# Altium schematic sheet 07.USB.SchDoc
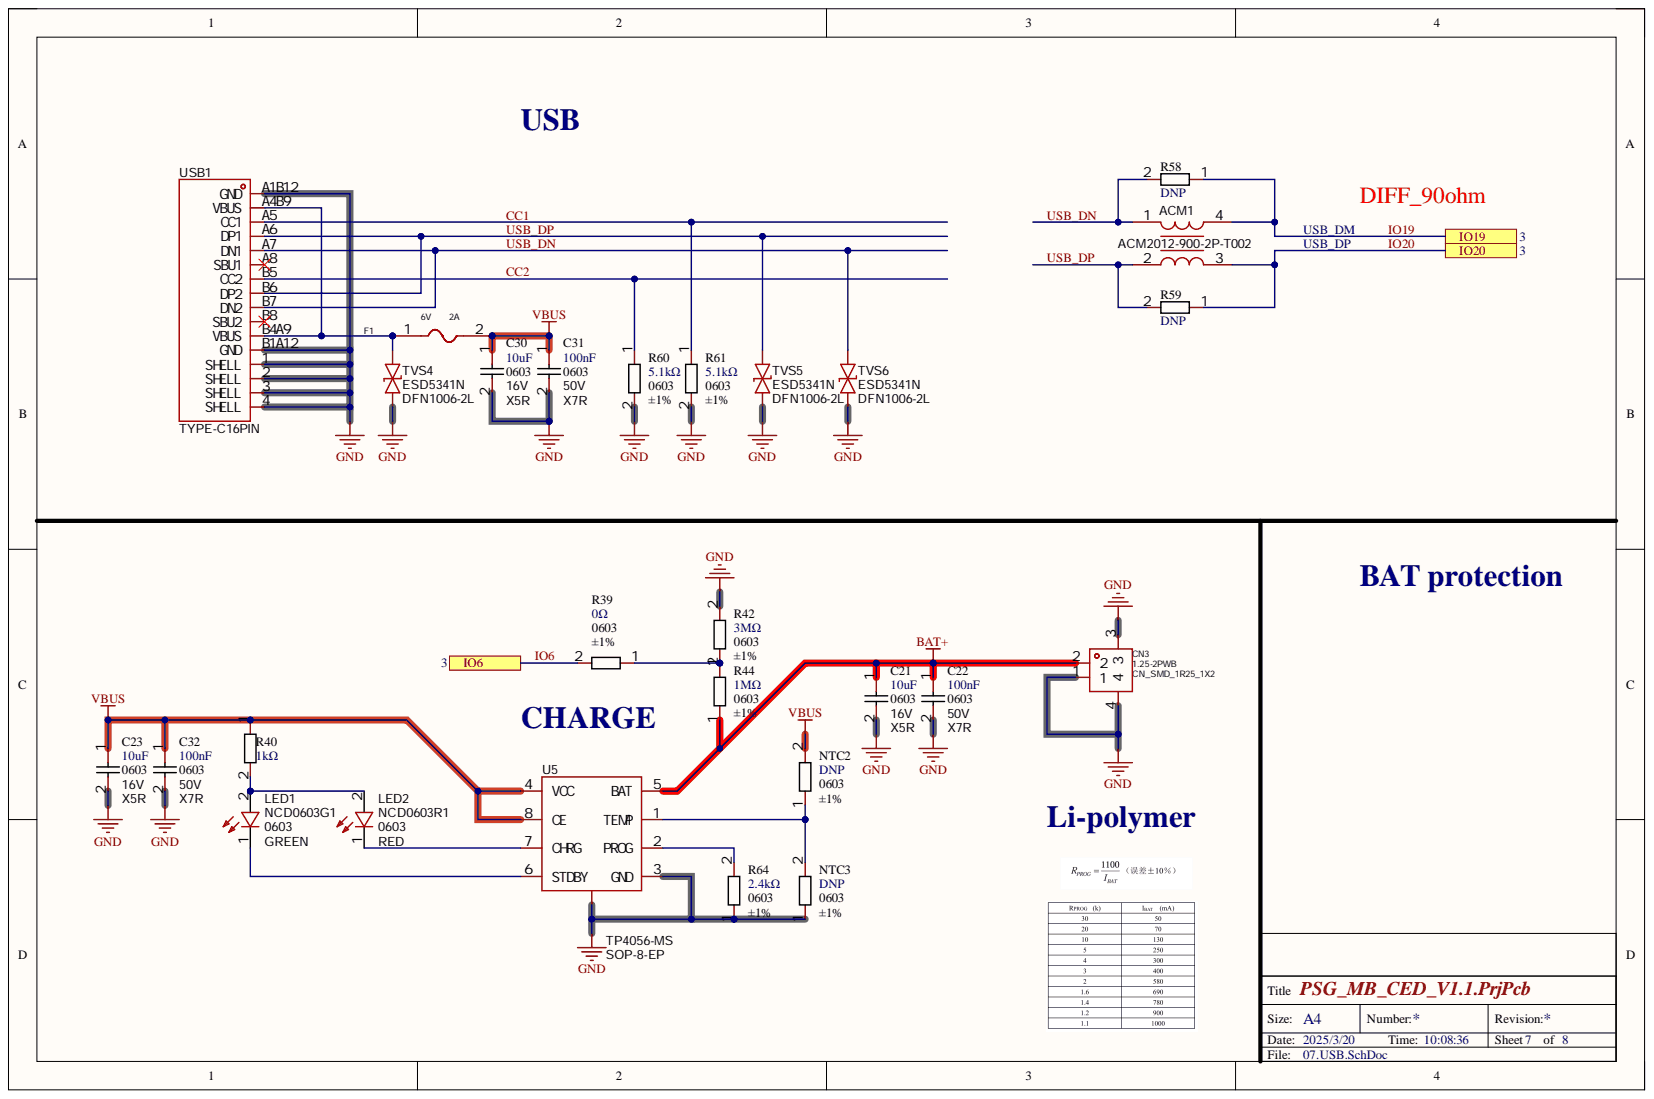
[diagram p0: 07.USB.SchDoc]

## Parts
ACM1  ACM2012-900-2P-T002  [ACM_SMD_4P_2012]
C21  10uF  Supplier Footprint: 0603  Temperature Coefficient: X5R  Voltage Rated: 16V  [C0603]
C22  100nF  Supplier Footprint: 0603  Temperature Coefficient: X7R  Voltage Rated: 50V  [C0603]
C23  10uF  Supplier Footprint: 0603  Temperature Coefficient: X5R  Voltage Rated: 16V  [C0603]
C30  10uF  Supplier Footprint: 0603  Temperature Coefficient: X5R  Voltage Rated: 16V  [C0603]
C31  100nF  Supplier Footprint: 0603  Temperature Coefficient: X7R  Voltage Rated: 50V  [C0603]
C32  100nF  Supplier Footprint: 0603  Temperature Coefficient: X7R  Voltage Rated: 50V  [C0603]
CN3  1.25-2PWB  [CN_SMD_1R25_1X2]
F1  Hold Current: 2A  Operating Voltage (Max): 6V  [F0805]  (hidden comment: SL0805200)
LED1  NCD0603G1  Emitted Color: GREEN  Supplier Footprint: 0603  [LED0603-G]
LED2  NCD0603R1  Emitted Color: RED  Supplier Footprint: 0603  [LED0603-R]
NTC2  DNP  Supplier Footprint: 0603  Tolerance: ±1%  [R0603]
NTC3  DNP  Supplier Footprint: 0603  Tolerance: ±1%  [R0603]
R39  0Ω  Supplier Footprint: 0603  Tolerance: ±1%  [R0603]
R40  1kΩ  [R0603]
R42  3MΩ  Supplier Footprint: 0603  [R0603]
R44  1MΩ  Supplier Footprint: 0603  Tolerance: ±1%  [R0603]
R58  DNP  [R0603]
R59  DNP  [R0603]
R60  5.1kΩ  Supplier Footprint: 0603  Tolerance: ±1%  [R0603]
R61  5.1kΩ  Supplier Footprint: 0603  Tolerance: ±1%  [R0603]
R64  2.4kΩ  Supplier Footprint: 0603  Tolerance: ±1%  [R0603]
TVS4  ESD5341N  Supplier Footprint: DFN1006-2L  [DFN1006-2L-BI]
TVS5  ESD5341N  Supplier Footprint: DFN1006-2L  [DFN1006-2L-BI]
TVS6  ESD5341N  Supplier Footprint: DFN1006-2L  [DFN1006-2L-BI]
U5  TP4056-MS  [SOP-8-EP]
USB1  TYPE-C16PIN  [USB-C-SMD_TYPE-C16PIN]

## Ports
IO6, IO19, IO20

## Nets
BAT+: C21.1 C22.1 CN3.2 R44.1 U5.5(BAT)
GND: C21.2 C22.2 C23.2 C30.2 C31.2 C32.2 CN3.1 CN3.3 CN3.4 NTC3.1 R42.2 R60.2 R61.2 R64.1 TVS4.2 TVS5.2 TVS6.2 U5.3(GND) U5.9 USB1.1(SHELL) USB1.2(SHELL) USB1.3(SHELL) USB1.4(SHELL) USB1.A1B12(GND) USB1.B1A12(GND)
VBUS: C23.1 C30.1 C31.1 C32.1 F1.2 NTC2.2 R40.1 U5.4(VCC) U5.8(CE)
CC1: R61.1 USB1.A5(CC1)
CC2: R60.1 USB1.B5(CC2)
IO6: R39.2 ; port IO6
IO19: ACM1.4 R58.1 ; port IO19
IO20: ACM1.3 R59.1 ; port IO20
USB_DN: ACM1.1 R58.2 TVS6.1 USB1.A7(DN1) USB1.B7(DN2)
USB_DP: ACM1.2 R59.2 TVS5.1 USB1.A6(DP1) USB1.B6(DP2)
NetF1_1: F1.1 TVS4.1 USB1.A4B9(VBUS) USB1.B4A9(VBUS)
NetLED1_1: LED1.1 U5.6(STDBY)
NetLED1_2: LED1.2 LED2.2 R40.2
NetLED2_1: LED2.1 U5.7(CHRG)
NetNTC2_1: NTC2.1 NTC3.2 U5.1(TEMP)
NetR39_1: R39.1 R42.1 R44.2
NetR64_2: R64.2 U5.2(PROG)

Not connected: USB1 A8(SBU1) B8(SBU2)

## Library data of the parts
ACM1 = ACM2012-900-2P-T002: Manufacturer: TDK; Add into BOM: yes; Current Rating: 400mA; DC Resistance (DCR): 190mΩ; Impedance @ Frequency: 90Ω@100MHz; Number of Lines: 2; Voltage Rating - DC: 50V; Component Kind: Standard; Convert to PCB: yes; Datasheet: https://atta.szlcsc.com/upload/public/pdf/source/20200629/C19972_344527C0CEDC971B6C9D0CA9AC65ADB7.pdf; JLCPCB Part Class: Extended Part; LCSC Part Name: 两路 贴片共模滤波器 阻抗90Ω@100MHz 停产; Manufacturer Part: ACM2012-900-2P-T002; Operating Temperature: -25℃~+85℃; Supplier: LCSC; Supplier Part: C19972; Symbol: ACM2012-900-2P-T002; Value: ACM2012-900-2P-T002; Withstanding Voltage: 50V
C21 C23 C30 = CC0603JRNPO9BN120: Manufacturer: YAGEO(国巨); Add into BOM: yes; Device: CC0603MRX5R7BB106; Name: 贴片电容; Tolerance: ±20%; Component Kind: Standard; Convert to PCB: yes; Datasheet: https://atta.szlcsc.com/upload/public/pdf/source/20180326/C109457_7BA80561F4CBA4554497C3A4BE33D2CE.pdf; JLCPCB Part Class: Extended Part; LCSC Part Name: 10uF ±20% 16V; Manufacturer Part: CC0603MRX5R7BB106; Supplier: LCSC; Supplier Part: C109457; Symbol: CC0603MRX5R7BB106; Value: 10uF
C22 C31 C32 = CC0603JRNPO9BN120: Manufacturer: YAGEO(国巨); Add into BOM: yes; Device: CC0603KRX7R9BB104; Name: 贴片电容; Tolerance: ±10%; Component Kind: Standard; Convert to PCB: yes; Datasheet: https://atta.szlcsc.com/upload/public/pdf/source/20180910/C282072_33301C7F631E79921B82A8DAC6BCC5B3.pdf; JLCPCB Part Class: Basic Part; LCSC Part Name: 100nF ±10% 50V; Manufacturer Part: CC0603KRX7R9BB104; Supplier: LCSC; Supplier Part: C14663; Symbol: CC0603KRX7R9BB104; Value: 100nF
CN3 = WAFER-PH2.0-2PWB: Manufacturer: DEALON(德艺隆); Add into BOM: yes; Number of PINs Per Row: 2; Pins Structure: 1x2P; Pitch: 1.25mm; Component Kind: Standard; Convert to PCB: yes; Current Rating (Max): -; Datasheet: https://atta.szlcsc.com/upload/public/pdf/source/20231123/1AE0876AE9C7DCFC0D68EAC4CBA881B0.pdf; JLCPCB Part Class: Extended Part; LCSC Part Name: 1x2P 间距:1.25mm 卧贴; Manufacturer Part: 1.25-2PWB; Mounting Style: 卧贴; Number of Pins: 2P; Number of Rows: 1; Operating Temperature Range: -40℃~+85℃; PCB3D: CN_SMD_2R0_1X2; Supplier: LCSC; Supplier Footprint: CN_SMD_1R25_1X2; Supplier Part: C2905009; Symbol: 1.25-2PWB; Value: 1.25-2PWB
F1 = SL0805200: Manufacturer: 台湾陆海; Add into BOM: yes; Current Rating (Max): 50A; Maximum Time to Trip: 5s; Power Dissipation: 1W; Resistance - Initial (Ri) (Min): 8mΩ; Resistance - Post Trip (R1) (Max): 55mΩ; Trip Current: 4A; Component Kind: Standard; Convert to PCB: yes; Datasheet: https://atta.szlcsc.com/upload/public/pdf/source/20160823/1471947506254.pdf; JLCPCB Part Class: Extended Part; LCSC Part Name: 6V 2A 0805自恢复; Manufacturer Part: SL0805200; Operating Temperature: -40℃~+70℃; PCB3D: F0805_L2.1-W1.4-H0.8; Supplier: LCSC; Supplier Footprint: 0805; Supplier Part: C70158; Symbol: SL0805200; Value: SL0805200
LED1 = NCD0603R1_C84263: Manufacturer: 国星光电; Add into BOM: yes; Diode Configuration: 独立二极管; Dominant Wavelength: 515nm~530nm; Forward Current: 10mA; Forward Voltage (VF): 2.6V~3.6V; Lens Color: 无色透明透镜; Luminous Intensity: 330mcd~900mcd; Power Dissipation: 72mW; Symbol: FC-D1608UGK-520D10; Viewing Angle: 130°; Color Temperature: -; Component Kind: Standard; Convert to PCB: yes; Datasheet: https://atta.szlcsc.com/upload/public/pdf/source/20200820/C84267_04BA7703640BAC111D09F5EFB971FBF3.pdf; JLCPCB Part Class: Extended Part; Lamp Holder Type: -; LCSC Part Name: NCD0603G1; Manufacturer Part: NCD0603G1; Mounting Sytle: -; Operating Temperature: -30℃~+85℃; Peak Wavelength: -; Supplier: LCSC; Supplier Part: C84267; Value: NCD0603R1_C84263
LED2 = NCD0603R1_C84263: Manufacturer: 国星光电; Add into BOM: yes; Diode Configuration: 独立二极管; Dominant Wavelength: 615nm~630nm; Forward Current: 20mA; Forward Voltage (VF): 1.6V~2.6V; Lens Color: 无色透明透镜; Luminous Intensity: 80mcd~220mcd; Power Dissipation: 65mW; Symbol: FC-DA1608HRK-620D; Viewing Angle: 130°; Color Temperature: -; Component Kind: Standard; Convert to PCB: yes; Datasheet: https://atta.szlcsc.com/upload/public/pdf/source/20200820/C84263_DABC6A75E58F576973B407FDBA283D60.pdf; JLCPCB Part Class: Extended Part; Lamp Holder Type: -; LCSC Part Name: NCD0603R1; Manufacturer Part: NCD0603R1; Mounting Sytle: -; Operating Temperature: -30℃~+85℃; Peak Wavelength: -; Supplier: LCSC; Supplier Part: C84263; Value: NCD0603R1_C84263
NTC2 NTC3 = 0603WAF1003T5E: Manufacturer: YAGEO(国巨); Add into BOM: yes; Device: RC0603FR-07100KL; Name: 贴片电阻; Overload Voltage (Max): 75V; Power(Watts): 100mW; Temperature Coefficient: ±100ppm/℃; Component Kind: Standard; Convert to PCB: yes; Datasheet: https://atta.szlcsc.com/upload/public/pdf/source/20140324/1457707028752.pdf; JLCPCB Part Class: Extended Part; LCSC Part Name: 厚膜电阻 100kΩ ±1% 100mW; Manufacturer Part: RC0603FR-07100KL; Operating Temperature Range: -55℃~+155℃; Supplier: LCSC; Supplier Part: C14675; Symbol: RC0603FR-07100KL; Type: 厚膜电阻; Value: DNP
R39 = 0603WAF1003T5E: Manufacturer: YAGEO(国巨); Add into BOM: yes; Device: RC0603FR-070RL; Name: 贴片电阻; Overload Voltage (Max): 75V; Power(Watts): 100mW; Component Kind: Standard; Convert to PCB: yes; Datasheet: https://atta.szlcsc.com/upload/public/pdf/source/20180316/70C0E2BDAE7FCC62C531A5A58D202EE1.pdf; JLCPCB Part Class: Extended Part; LCSC Part Name: 厚膜电阻 0Ω ±1% 100mW; Manufacturer Part: RC0603FR-070RL; Operating Temperature Range: -55℃~+155℃; Supplier: LCSC; Supplier Part: C100044; Symbol: RC0603FR-070RL; Temperature Coefficient: -; Type: 厚膜电阻; Value: 0Ω
R40 = 0603WAF1003T5E: Manufacturer: YAGEO(国巨); Add into BOM: yes; Device: RC0603FR-071KL; Name: 贴片电阻; Overload Voltage (Max): 75V; Power(Watts): 100mW; Temperature Coefficient: ±100ppm/℃; Component Kind: Standard; Convert to PCB: yes; Datasheet: https://atta.szlcsc.com/upload/public/pdf/source/20180316/70C0E2BDAE7FCC62C531A5A58D202EE1.pdf; JLCPCB Part Class: Extended Part; LCSC Part Name: 厚膜电阻 1kΩ ±1% 100mW; Manufacturer Part: RC0603FR-071KL; Operating Temperature Range: -55℃~+155℃; Supplier: LCSC; Supplier Part: C22548; Symbol: RC0603FR-071KL; Type: 厚膜电阻; Value: 1kΩ
R42 = 0603WAF1003T5E: Manufacturer: YAGEO(国巨); Add into BOM: yes; Device: RC0603FR-073ML; Name: 贴片电阻; Overload Voltage (Max): 75V; Power(Watts): 100mW; Temperature Coefficient: ±100ppm/℃; Tolerance: ±1%; Component Kind: Standard; Convert to PCB: yes; Datasheet: https://atta.szlcsc.com/upload/public/pdf/source/20180821/C275644_0D56F95EFF785E7D9A1FEF436B37DA78.pdf; JLCPCB Part Class: Extended Part; LCSC Part Name: 厚膜电阻 3MΩ ±1% 100mW; Manufacturer Part: RC0603FR-073ML; Operating Temperature Range: -55℃~+155℃; Supplier: LCSC; Supplier Part: C126361; Symbol: RC0603FR-073ML; Type: 厚膜电阻; Value: 3MΩ
R44 = 0603WAF1003T5E: Manufacturer: YAGEO(国巨); Add into BOM: yes; Device: RC0603FR-071ML; Name: 贴片电阻; Overload Voltage (Max): 75V; Power(Watts): 100mW; Temperature Coefficient: ±100ppm/℃; Component Kind: Standard; Convert to PCB: yes; Datasheet: https://atta.szlcsc.com/upload/public/pdf/source/20181102/C105578_67A9388250AF143DE4682E62257D2969.pdf; JLCPCB Part Class: Extended Part; LCSC Part Name: 厚膜电阻 1MΩ ±1% 100mW; Manufacturer Part: RC0603FR-071ML; Operating Temperature Range: -55℃~+155℃; Supplier: LCSC; Supplier Part: C105578; Symbol: RC0603FR-071ML; Type: 厚膜电阻; Value: 1MΩ
R58 R59 = 0603WAF1003T5E: Manufacturer: YAGEO(国巨); Add into BOM: yes; Device: RC0603FR-0710KL; Name: 贴片电阻; Overload Voltage (Max): 75V; Power(Watts): 100mW; Temperature Coefficient: ±100ppm/℃; Component Kind: Standard; Convert to PCB: yes; Datasheet: https://atta.szlcsc.com/upload/public/pdf/source/20180927/C295883_E8D83085EA81BD7DE0B2B681138C15EE.pdf; JLCPCB Part Class: Extended Part; LCSC Part Name: 厚膜电阻 10kΩ ±1% 100mW; Manufacturer Part: RC0603FR-0710KL; Operating Temperature Range: -55℃~+155℃; Supplier: LCSC; Supplier Part: C98220; Symbol: RC0603FR-0710KL; Type: 厚膜电阻; Value: DNP
R60 R61 = 0603WAF1003T5E: Manufacturer: YAGEO(国巨); Add into BOM: yes; Device: RC0603FR-075K1L; Name: 贴片电阻; Overload Voltage (Max): 75V; Power(Watts): 100mW; Temperature Coefficient: ±100ppm/℃; Component Kind: Standard; Convert to PCB: yes; Datasheet: https://atta.szlcsc.com/upload/public/pdf/source/20180316/70C0E2BDAE7FCC62C531A5A58D202EE1.pdf; JLCPCB Part Class: Extended Part; LCSC Part Name: 厚膜电阻 5.1kΩ ±1% 100mW; Manufacturer Part: RC0603FR-075K1L; Operating Temperature Range: -55℃~+155℃; Supplier: LCSC; Supplier Part: C105580; Symbol: RC0603FR-075K1L; Type: 厚膜电阻; Value: 5.1kΩ
R64 = 0603WAF1003T5E: Manufacturer: YAGEO(国巨); Add into BOM: yes; Device: RC0603FR-072K4L; Name: 贴片电阻; Overload Voltage (Max): 75V; Power(Watts): 100mW; Temperature Coefficient: ±100ppm/℃; Component Kind: Standard; Convert to PCB: yes; Datasheet: https://atta.szlcsc.com/upload/public/pdf/source/20180821/C275644_0D56F95EFF785E7D9A1FEF436B37DA78.pdf; JLCPCB Part Class: Extended Part; LCSC Part Name: 厚膜电阻 2.4kΩ ±1% 100mW; Manufacturer Part: RC0603FR-072K4L; Operating Temperature Range: -55℃~+155℃; Supplier: LCSC; Supplier Part: C114611; Symbol: RC0603FR-072K4L; Type: 厚膜电阻; Value: 2.4kΩ
TVS4-TVS6 = ESD5341N_C20612964: Manufacturer: KUU; Add into BOM: yes; Component Kind: Standard; Convert to PCB: yes; Datasheet: https://atta.szlcsc.com/upload/public/pdf/source/20240126/9EE8C033ACD76A81C21292FAA1DFE4C1.pdf; JLCPCB Part Class: Extended Part; LCSC Part Name: ESD静电保护管; Manufacturer Part: ESD5341N; Supplier: LCSC; Supplier Part: C20612964; Symbol: ESD5341N_C20612964; Value: ESD5341N
U5 = TP4056-42-EMSOP8: Manufacturer: MSKSEMI(美森科); Add into BOM: yes; Component Kind: Standard; Convert to PCB: yes; Datasheet: https://atta.szlcsc.com/upload/public/pdf/source/20230808/7F9175670C53D6763A7A5691214AEA38.pdf; JLCPCB Part Class: Extended Part; LCSC Part Name: TP4056-MS; Manufacturer Part: TP4056-MS; PCB3D: MSOP-8_L3.0-W3.0-P0.65-LS5.0-BR-EP; Supplier: LCSC; Supplier Footprint: SOP-8-EP; Supplier Part: C7473158; Symbol: TP4056-MS; Value: TP4056-MS
USB1 = TYPE-C16PIN: Manufacturer: SHOU HAN(首韩); Add into BOM: yes; Connect-Disconnect Life: 1万次; Connector Type: Type-C; Core Colour: 黑色; Current Rating - Power (Max): 3A; Gender: 母; Number of Contacts: 16P; Number of Ports: 1; Rated Voltage (Max): 30V; Component Kind: Standard; Convert to PCB: yes; Datasheet: https://atta.szlcsc.com/upload/public/pdf/source/20190521/C393939_7B9043D4CF1026AF443AB60D91FBCD5C.pdf; JLCPCB Part Class: Extended Part; LCSC Part Name: Type-C 母 卧贴; Manufacturer Part: TYPE-C16PIN; Mounting Style: 卧贴; Operating Temperature Range: -40℃~+85℃; PCB3D: USB-C-SMD_TYPE-C16PIN; Standard: USB 3.1; Supplier: LCSC; Supplier Footprint: SMD; Supplier Part: C393939; Symbol: TYPE-C16PIN; Value: TYPE-C16PIN
